# Altium schematic sheet Sheet10.SchDoc
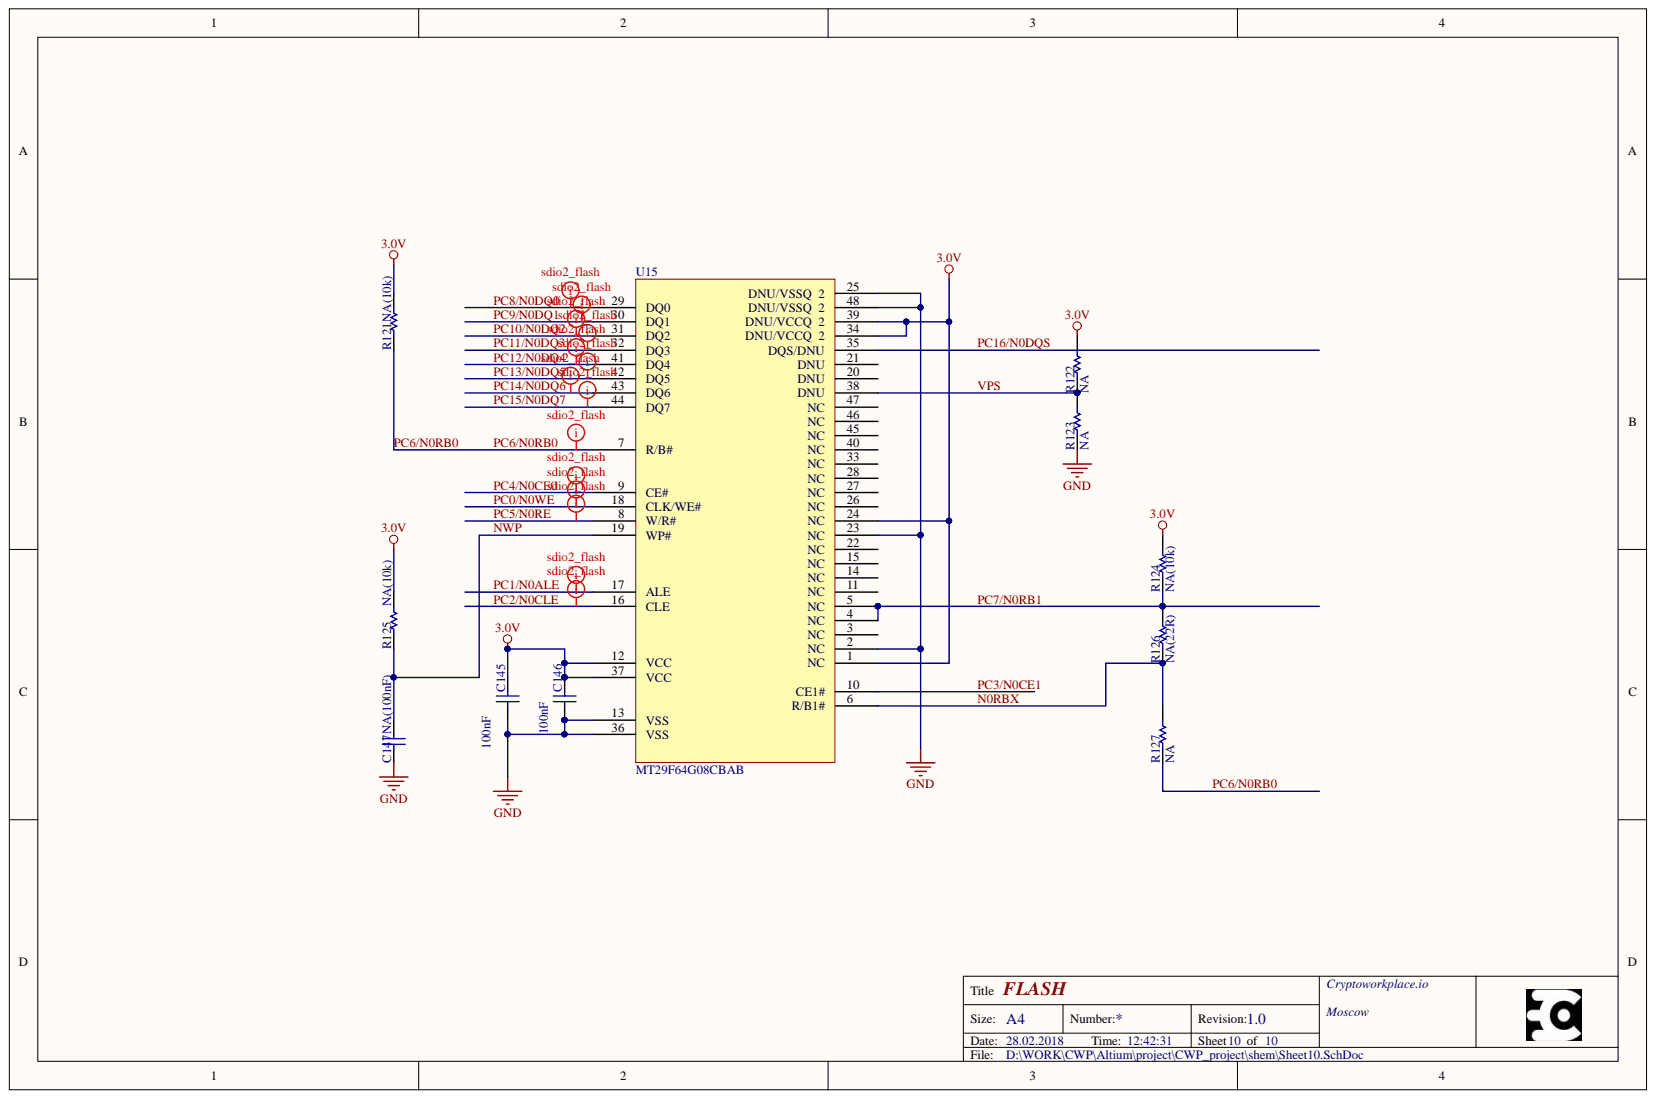
[diagram p0: Sheet10.SchDoc]

## Parts
C145  value 100nF  [0402(CAPC1005X06N)]  (hidden comment: 0402)
C146  value 100nF  [0402(CAPC1005X06N)]  (hidden comment: 0402)
C147  value NA(100nF)  [0402(CAPC1005X06N)]  (hidden comment: 0402)
R121  value NA(10k)  [0402(RESC1005X04N)]  (hidden comment: Res1)
R122  value NA  [0402(RESC1005X04N)]  (hidden comment: Res1)
R123  value NA  [0402(RESC1005X04N)]  (hidden comment: Res1)
R124  value NA(10k)  [0402(RESC1005X04N)]  (hidden comment: Res1)
R125  value NA(10k)  [0402(RESC1005X04N)]  (hidden comment: Res1)
R126  value NA(22R)  [0402(RESC1005X04N)]  (hidden comment: Res1)
R127  value NA  [0402(RESC1005X04N)]  (hidden comment: Res1)
U15  MT29F64G08CBAB  [TSSOP50P2000X120-48N]

## Nets
3.0V: C145.2 C146.2 R121.2 R122.2 R124.2 R125.2 U15.1(NC) U15.12(VCC) U15.24(NC) U15.34 U15.37(VCC) U15.39
GND: C145.1 C146.1 C147.1 R123.1 U15.2(NC) U15.13(VSS) U15.23(NC) U15.25 U15.36(VSS) U15.48
N0RBX: R126.1 R127.2 U15.6(R/B1#)
NWP: C147.2 R125.1 U15.19(WP#)
PC0/N0WE: U15.18(CLK/WE#)
PC1/N0ALE: U15.17(ALE)
PC2/N0CLE: U15.16(CLE)
PC3/N0CE1: U15.10(CE1#)
PC4/N0CE0: U15.9(CE#)
PC5/N0RE: U15.8(W/R#)
PC6/N0RB0: R121.1 R127.1 U15.7(R/B#)
PC7/N0RB1: R124.1 R126.2 U15.4(NC) U15.5(NC)
PC8/N0DQ0: U15.29(DQ0)
PC9/N0DQ1: U15.30(DQ1)
PC10/N0DQ2: U15.31(DQ2)
PC11/N0DQ3: U15.32(DQ3)
PC12/N0DQ4: U15.41(DQ4)
PC13/N0DQ5: U15.42(DQ5)
PC14/N0DQ6: U15.43(DQ6)
PC15/N0DQ7: U15.44(DQ7)
PC16/N0DQS: U15.35(DQS/DNU)
VPS: R122.1 R123.2 U15.38(DNU)

Not connected: U15 3(NC) 11(NC) 14(NC) 15(NC) 20(DNU) 21(DNU) 22(NC) 26(NC) 27(NC) 28(NC) 33(NC) 40(NC) 45(NC) 46(NC) 47(NC)

## Library data of the parts
C145-C147 = Cap: Capacitor; Component Kind: Standard; LatestRevisionDate: 17-Jul-2002; LatestRevisionNote: Re-released for DXP Platform.; Library Name: Miscellaneous Devices.IntLib; PackageDescription: Radial Cap, Thru-Hole; 2 Leads; 0.3 in Pin Spacing; PackageReference: RAD-0.3; Published: 8-Jun-2000; Signal Integrity: Cap; Simulation: CAP; Publisher: Altium Limited
R121-R127 = Res1: Resistor; Component Kind: Standard; LatestRevisionDate: 17-Jul-2002; LatestRevisionNote: Re-released for DXP Platform.; Library Name: Miscellaneous Devices.IntLib; PackageDescription: Axial Device, Thru-Hole; 2 Leads; 0.3 in Pin Spacing; PackageReference: AXIAL-0.3; Published: 8-Jun-2000; Signal Integrity: Res; Simulation: RESISTOR; Publisher: Altium Limited
U15 = MT29F64G08CBAB: MT29F64G08CBAB (WP); Component Kind: Standard; Library Name: Micron.SchLib
